# Altium schematic sheet CAN.SchDoc(U_CAN)
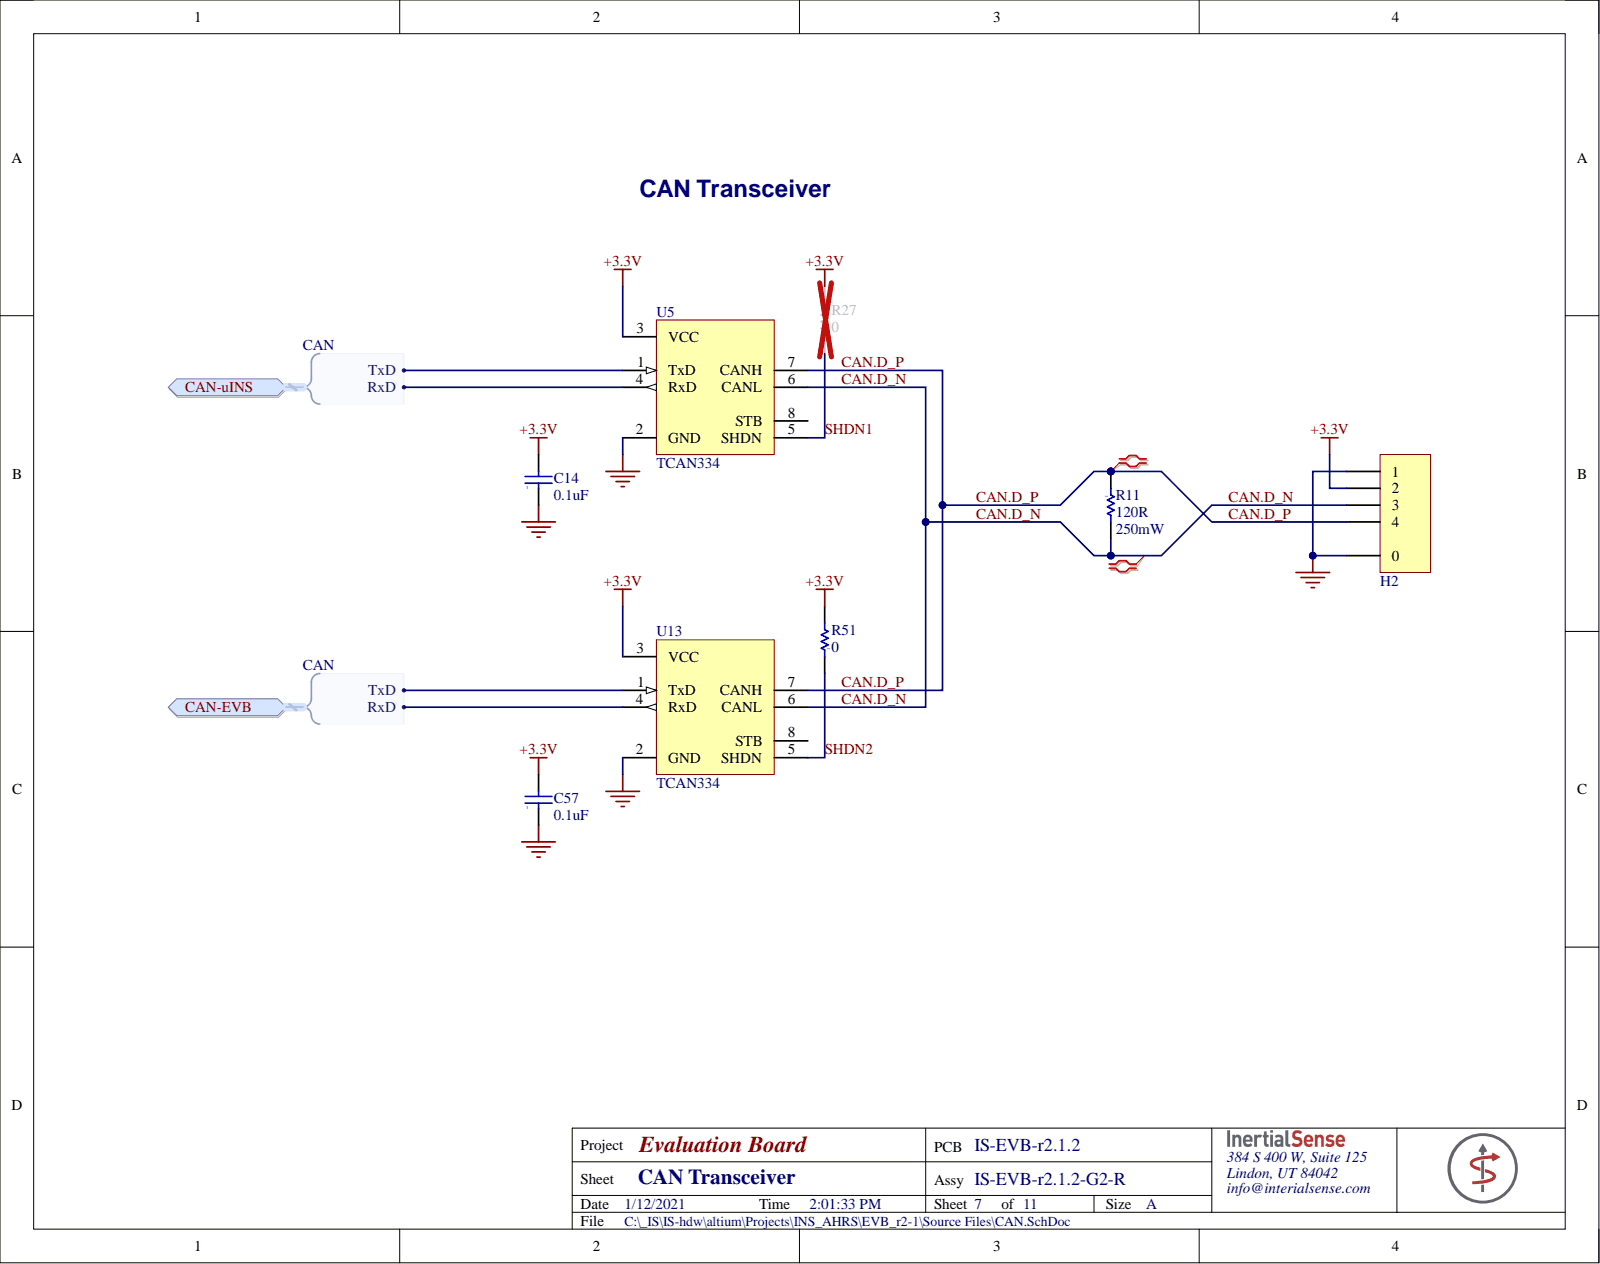
[diagram p0: CAN.SchDoc]

## Parts
C14  value 0.1uF  [0402]  (hidden comment: Cap)
C57  value 0.1uF  [0402]  (hidden comment: Cap)
H2  Hdr - 4pin - mount  [SM04B-GHS-TB(LF)(SN)]  (value not drawn)
R11  value 120R  Max Power Dissipation: 250mW  Resistance: 120R  [R0603]  (hidden comment: Res)
R27  value 0  [R0402]  (hidden comment: Res)
R51  value 0  [R0402]  (hidden comment: Res)
U5  TCAN334  [SOT23-8-65P280X145-8N]
U13  TCAN334  [SOT23-8-65P280X145-8N]

## Ports
CAN-EVB, CAN-EVB.RxD, CAN-EVB.TxD, CAN-uINS, CAN-uINS.RxD, CAN-uINS.TxD

## Nets
+3.3V: C14.2 C57.2 H2.2 R27.2 R51.2 U5.3(VCC) U13.3(VCC)
GND: C14.1 C57.1 H2.0 H2.1 U5.2(GND) U13.2(GND)
CAN.D_N: H2.3 R11.2 U5.6(CANL) U13.6(CANL)
CAN.D_P: H2.4 R11.1 U5.7(CANH) U13.7(CANH)
SHDN1: R27.1 U5.5(SHDN)
SHDN2: R51.1 U13.5(SHDN)
NetU5_1: U5.1(TxD) ; port CAN-uINS
NetU5_4: U5.4(RxD) ; port CAN-uINS
NetU5_8: U5.8(STB)
NetU13_1: U13.1(TxD) ; port CAN-EVB
NetU13_4: U13.4(RxD) ; port CAN-EVB
NetU13_8: U13.8(STB)

## Library data of the parts
C14 C57 = Cap: CAP CER .10UF 50V X7R 10% 0402; Manufacturer: TDK Corporation; Manufacturer Part Number: C1005X7R1H104K; Capacitance: 0.1µF; Applications: General Purpose; Category: Capacitors; Component Kind: Standard; ComponentLink1Description: http://www.tdk.co.jp/tefe02/e412_c.pdf; ComponentLink1URL: http://www.tdk.co.jp/tefe02/e412_c.pdf; Features: -; Height: -; LatestRevisionDate: 17-Jul-2002; LatestRevisionNote: Re-released for DXP Platform.; Lead Spacing: -; Lead Style: -; Library Name: General.SchLib; Mounting Type: Surface Mount, MLCC; Operating Temperature: -55°C ~ 125°C; Package / Case: 0402 (1005 Metric); Packaging: Cut Tape (CT); Pricing 1: 1=0.3, 10=0.2, 100=0.08, 250=0.05752, 500=0.041, 1000=0.03 (USD); Published: 8-Jun-2000; Ratings: -; RoHS: RoHS Compliant; Signal Integrity: Cap; Simulation: CAP; Size / Dimension: 0.039" L x 0.020" W (1.00mm x 0.50mm); Stock 1: 174080; Supplier 1: Digi-Key; Supplier Part Number 1: 445-5932-1-ND; Temperature Coefficient: X7R; Thickness: 0.022" (0.55mm); Tolerance: ±10%; Voltage - Rated: 50V; Publisher: Altium Limited
H2 = Hdr - 4pin - mount: CONN HEADER GH SIDE 4POS 1.25MM; Manufacturer: JST; Manufacturer Part Number: SM04B-GHS-TB(LF)(SN)-; Connector Type: Outer Shroud Contact; Number of Positions: 4; Pitch: 0.049inch; Colour: Natural; Component Kind: Standard; Contact Plating: Tin; Fastening Type: Ramp; Library Name: Connectors.SchLib; Mount: Surface Mount; Number of Rows: 1; Packaging: Cut Tape; Pricing 1: 1=0.45, 10=0.423, 100=0.3244, 500=0.28212, 1000=0.23274 (USD); Stock 1: 1762; Supplier 1: Digi-Key; Supplier Part Number 1: 455-1566-1-ND; Termination: Solder
R11 = Res: RES SMD 120 OHM 5% 1/4W 0603; Manufacturer: Rohm; Manufacturer Part Number: ESR03EZPJ121; Temperature Coefficient: 200ppm/°C; Case Code (Imperial): 0603; Case Code (Metric): 1608; Case/Package: 0603; Component Kind: Standard; ComponentLink1Description: https://datasheet.ciiva.com/2495/esr-2495284.pdf; ComponentLink1URL: https://datasheet.ciiva.com/2495/esr-2495284.pdf; ComponentLink2Description: https://datasheet.ciiva.com/2495/esr-2495285.pdf; ComponentLink2URL: https://datasheet.ciiva.com/2495/esr-2495285.pdf; ComponentLink3Description: https://datasheet.ciiva.com/2495/esr-2495286.pdf; ComponentLink3URL: https://datasheet.ciiva.com/2495/esr-2495286.pdf; Composition: Thick Film; Height: 0.022inch; Lead Free: Lead Free; Length: 0.063inch; Library Name: General.SchLib; Max Operating Temperature: 155°C; Min Operating Temperature: -55°C; Number of Terminations: 2; Package Quantity: 1; Packaging: Tape and Reel; Power Rating: 250mW; Pricing 1: 1=0.1, 10=0.087, 100=0.0333, 1000=0.01464, 2500=0.01264 (USD); Signal Integrity: Res; Simulation: RES; Stock 1: 89476; Supplier 1: Digi-Key; Supplier Part Number 1: RHM120DDKR-ND; Tolerance: 5%; Width: 0.031inch
R27 R51 = Res: RES SMD 0 OHM JUMPER 1/16W 0402; Manufacturer: Yageo; Manufacturer Part Number: RC0402JR-070RL; Max Power Dissipation: 63mW; Power Rating: 62.5mW; Resistance: 0mR; Resistance Tolerance: ± 5%; Resistor Case Style: 0402 [1005 Metric]; Resistor Element Type: Thick Film; SVHC: No SVHC (20-Jun-2016); Temperature Coefficient: 200ppm/°C; Tolerance: 5%; Voltage Rating: 50V; Automotive Qualification Standard: -; Case Code (Imperial): 0402; Case Code (Metric): 1005; Case/Package: 0402; Component Kind: Standard; ComponentLink1Description: https://datasheet.ciiva.com/2452/pyu-rc0402-51-rohs-l-6-2452608.pdf; ComponentLink1URL: https://datasheet.ciiva.com/2452/pyu-rc0402-51-rohs-l-6-2452608.pdf; ComponentLink2Description: https://datasheet.ciiva.com/2452/pyu-r-int-thick-7-2452609.pdf; ComponentLink2URL: https://datasheet.ciiva.com/2452/pyu-r-int-thick-7-2452609.pdf; ComponentLink3Description: https://datasheet.ciiva.com/2452/pyu-r-int-thick-7-2452610.pdf; ComponentLink3URL: https://datasheet.ciiva.com/2452/pyu-r-int-thick-7-2452610.pdf; ComponentLink4Description: https://datasheet.ciiva.com/11808/0900766b80c66297-11808195.pdf; ComponentLink4URL: https://datasheet.ciiva.com/11808/0900766b80c66297-11808195.pdf; ComponentLink5Description: https://datasheet.ciiva.com/8896/pyu-rc0402-51-rohs-l-6-8896201.pdf; ComponentLink5URL: https://datasheet.ciiva.com/8896/pyu-rc0402-51-rohs-l-6-8896201.pdf; ComponentLink6Description: https://datasheet.ciiva.com/28386/pyu-rc0402-51-rohs-l-6-28386633.pdf; ComponentLink6URL: https://datasheet.ciiva.com/28386/pyu-rc0402-51-rohs-l-6-28386633.pdf; ComponentLink7Description: https://datasheet.ciiva.com/22747/1018594-22747515.pdf; ComponentLink7URL: https://datasheet.ciiva.com/22747/1018594-22747515.pdf; ComponentLink8Description: https://datasheet.ciiva.com/15189/getdatasheetpartid-326379-15189533.pdf; ComponentLink8URL: https://datasheet.ciiva.com/15189/getdatasheetpartid-326379-15189533.pdf; ComponentLink9Description: https://datasheet.ciiva.com/7983/1018594-7983535.pdf; ComponentLink9URL: https://datasheet.ciiva.com/7983/1018594-7983535.pdf; Composition: Thick Film; Contact Plating: Matte,Tin; Depth: 0.5mm; Features: Moisture Resistant; Height: 0.016inch; Lead Free: Lead Free; Length: 1mm; Library Name: General.SchLib; Max Operating Temperature: 155°C; Military Standard: Not; Min Operating Temperature: -55°C; MSL: MSL 1 - Unlimited; Number of Terminations: 2; Package Quantity: 1; Packaging: Tape and Reel; Pricing 1: 1=0.1, 10=0.017, 25=0.0124, 50=0.0096, 100=0.007, 250=0.00536, 500=0.00428, 1000=0.00315, 5000=0.00226 (USD); Product Range: -; Radiation Hardening: No; REACH SVHC: Unknown; Signal Integrity: Res; Simulation: RES; Stock 1: 39749506; Supplier 1: Digi-Key; Supplier Part Number 1: 311-0.0JRDKR-ND; Termination: SMD/SMT; Width: 0.02inch
U5 U13 = TCAN334: IC TXRX CAN 3.3V SOT23-8; Manufacturer: Texas Instruments; Manufacturer Part Number: TCAN334DCNR; Component Kind: Standard; Lead Free: Lead Free; Library Name: ICs.SchLib; Max Operating Temperature: 125°C; Min Operating Temperature: -40°C; Pricing 1: 1=2.6, 10=2.336, 100=1.878, 500=1.54294, 1000=1.27844 (USD); Stock 1: 2931; Supplier 1: Digi-Key; Supplier Part Number 1: 296-47830-1-ND
